# Altium schematic sheet Schematics.SchDoc
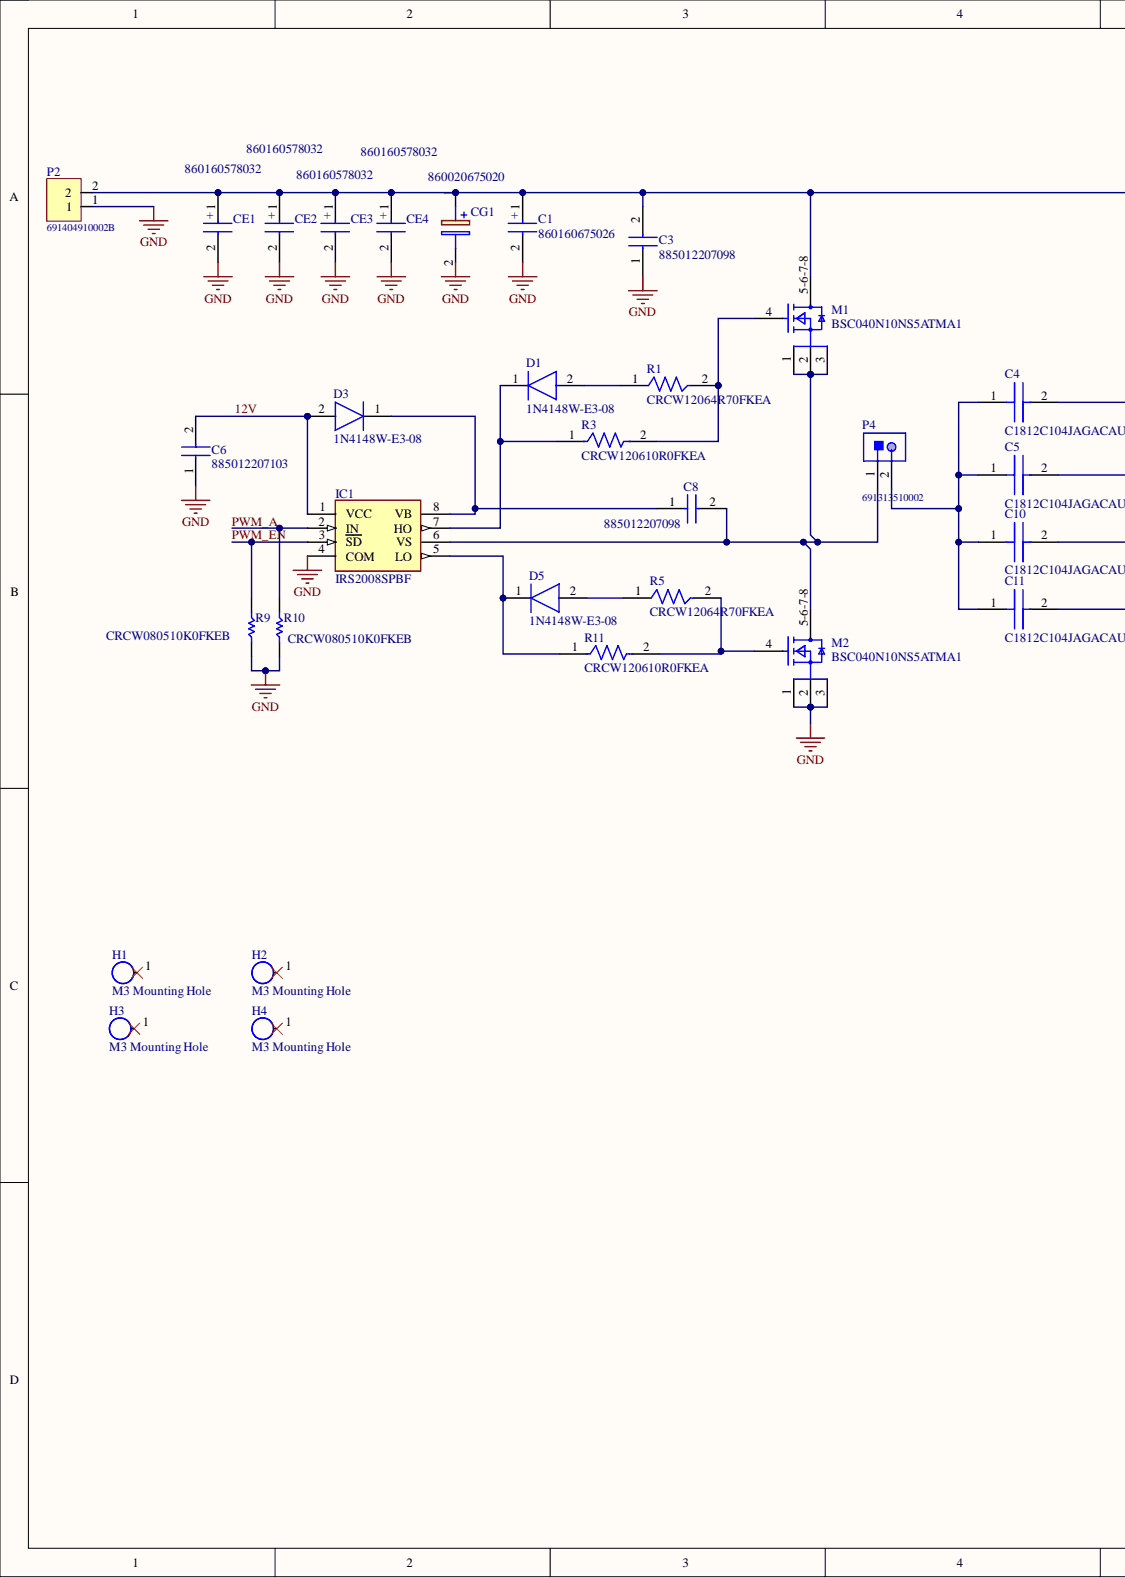
[diagram p0: Schematics.SchDoc, left]
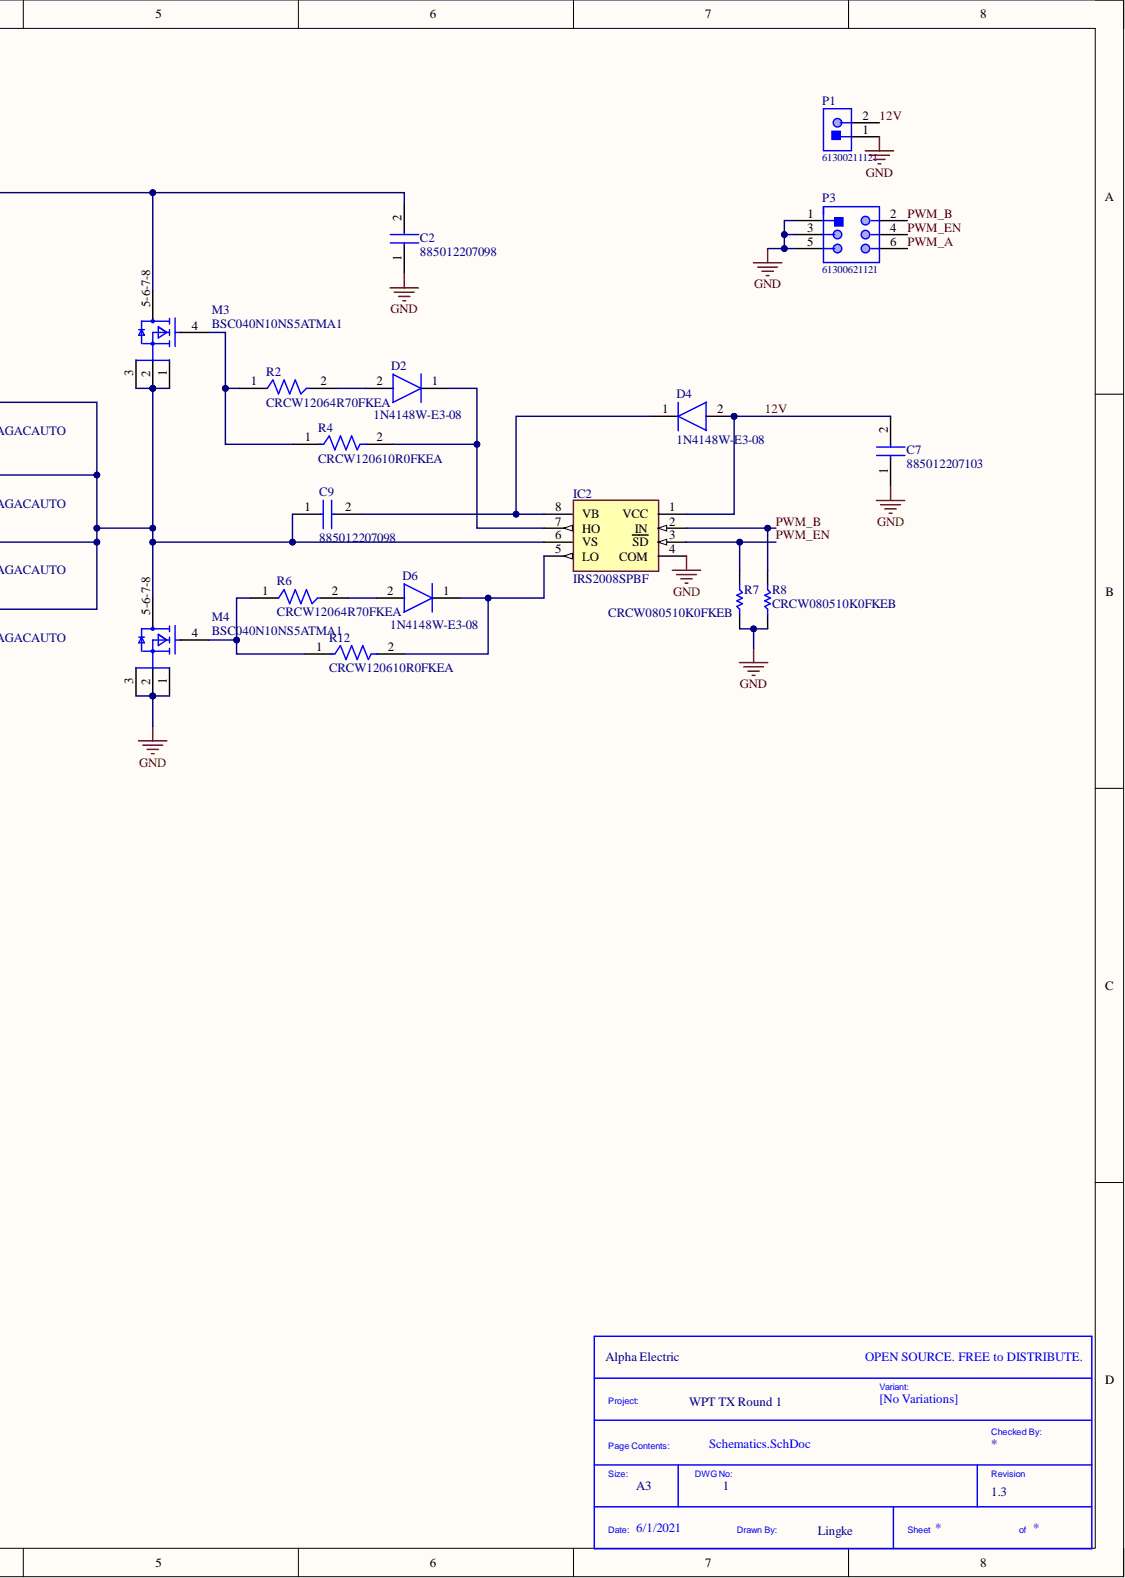
[diagram p1: Schematics.SchDoc, right]

## Parts
C1  860160675026  [CAPPRD500W62D1000H1750]
C2  885012207098  [CAPC2012X90N]
C3  885012207098  [CAPC2012X90N]
C4  C1812C104JAGACAUTO  [CAPC4532X220N]
C5  C1812C104JAGACAUTO  [CAPC4532X220N]
C6  885012207103  [CAPC2012X135N]
C7  885012207103  [CAPC2012X135N]
C8  885012207098  [CAPC2012X90N]
C9  885012207098  [CAPC2012X90N]
C10  C1812C104JAGACAUTO  [CAPC4532X220N]
C11  C1812C104JAGACAUTO  [CAPC4532X220N]
CE1  860160578032  [CAPPRD500W62D1300H2650]
CE2  860160578032  [CAPPRD500W62D1300H2650]
CE3  860160578032  [CAPPRD500W62D1300H2650]
CE4  860160578032  [CAPPRD500W62D1300H2650]
CG1  860020675020  [CAPPRD500W62D1000H1400]
D1  1N4148W-E3-08  [SOD3716X135N]
D2  1N4148W-E3-08  [SOD3716X135N]
D3  1N4148W-E3-08  [SOD3716X135N]
D4  1N4148W-E3-08  [SOD3716X135N]
D5  1N4148W-E3-08  [SOD3716X135N]
D6  1N4148W-E3-08  [SOD3716X135N]
H1  M3 Mounting Hole  [M3 Hole]
H2  M3 Mounting Hole  [M3 Hole]
H3  M3 Mounting Hole  [M3 Hole]
H4  M3 Mounting Hole  [M3 Hole]
IC1  IRS2008SPBF  [SOIC127P600X175-8N]
IC2  IRS2008SPBF  [SOIC127P600X175-8N]
M1  BSC040N10NS5ATMA1  [FP-PG-TDSON-8-MFG]
M2  BSC040N10NS5ATMA1  [FP-PG-TDSON-8-MFG]
M3  BSC040N10NS5ATMA1  [FP-PG-TDSON-8-MFG]
M4  BSC040N10NS5ATMA1  [FP-PG-TDSON-8-MFG]
P1  61300211121  [61300211121]
P2  691404910002B  [691404910002B]
P3  61300621121  [61300621121]
P4  691313510002  [691313510002]
R1  CRCW12064R70FKEA  [FP-CRCW1206-e3-IPC_A]
R2  CRCW12064R70FKEA  [FP-CRCW1206-e3-IPC_A]
R3  CRCW120610R0FKEA  [FP-CRCW1206-e3-IPC_A]
R4  CRCW120610R0FKEA  [FP-CRCW1206-e3-IPC_A]
R5  CRCW12064R70FKEA  [FP-CRCW1206-e3-IPC_A]
R6  CRCW12064R70FKEA  [FP-CRCW1206-e3-IPC_A]
R7  CRCW080510K0FKEB  [RESC2013X50X30ML20T20]
R8  CRCW080510K0FKEB  [RESC2013X50X30ML20T20]
R9  CRCW080510K0FKEB  [RESC2013X50X30ML20T20]
R10  CRCW080510K0FKEB  [RESC2013X50X30ML20T20]
R11  CRCW120610R0FKEA  [FP-CRCW1206-e3-IPC_A]
R12  CRCW120610R0FKEA  [FP-CRCW1206-e3-IPC_A]

## Nets
GND: C1.2 C2.1 C3.1 C6.1 C7.1 CE1.2 CE2.2 CE3.2 CE4.2 CG1.2 IC1.4(COM) IC2.4(COM) M2.1 M2.2 M2.3 M4.1 M4.2 M4.3 P1.1 P2.1 P3.1 P3.3 P3.5 R7.1 R8.1 R9.1 R10.1
12V: C6.2 C7.2 D3.2 D4.2 IC1.1(VCC) IC2.1(VCC) P1.2
PWM_A: IC1.2(IN) P3.6 R10.2
PWM_B: IC2.2(IN) P3.2 R8.2
PWM_EN: IC1.3(SD) IC2.3(SD) P3.4 R7.2 R9.2
NetC1_1: C1.1 C2.2 C3.2 CE1.1 CE2.1 CE3.1 CE4.1 CG1.1 M1.5-6-7-8 M3.5-6-7-8 P2.2
NetC4_1: C4.1 C5.1 C10.1 C11.1 P4.2
NetC4_2: C4.2 C5.2 C9.1 C10.2 C11.2 IC2.6(VS) M3.1 M3.2 M3.3 M4.5-6-7-8
NetC8_1: C8.1 D3.1 IC1.8(VB)
NetC8_2: C8.2 IC1.6(VS) M1.1 M1.2 M1.3 M2.5-6-7-8 P4.1
NetC9_2: C9.2 D4.1 IC2.8(VB)
NetD1_1: D1.1 IC1.7(HO) R3.1
NetD1_2: D1.2 R1.1
NetD2_1: D2.1 IC2.7(HO) R4.2
NetD2_2: D2.2 R2.2
NetD5_1: D5.1 IC1.5(LO) R11.1
NetD5_2: D5.2 R5.1
NetD6_1: D6.1 IC2.5(LO) R12.2
NetD6_2: D6.2 R6.2
NetM1_4: M1.4 R1.2 R3.2
NetM2_4: M2.4 R5.2 R11.2
NetM3_4: M3.4 R2.1 R4.1
NetM4_4: M4.4 R6.1 R12.1

Not connected: H1 1; H2 1; H3 1; H4 1

## Library data of the parts
C1 = Input Cap 220uF: Capacitor 220uF/50V; Manufacturer_Name: Wurth Elektronik; Component Kind: Standard; Height: 17.5mm; Library Name: Symbol Library.SchLib; Manufacturer_Part_Number: 860160675026; Supplier 1: Mouser; Supplier Part Number 1: 710-860160675026
C2 C3 C8 C9 = Input Cap 100nF: Capacitor 0.1uF/50V X7R; Manufacturer_Name: Wurth Elektronik; Component Kind: Standard; Datasheet Link: https://componentsearchengine.com//885012207098.pdf; Height: 0.9mm; Library Name: Symbol Library.SchLib; Manufacturer_Part_Number: 885012207098; Mouser2 Part Number: 710-885012207098; Mouser2 Price/Stock: https://www.mouser.com/Search/Refine.aspx?Keyword=710-885012207098; Supplier 1: Mouser; Supplier Part Number 1: 710-885012207098
C4 C5 C10 C11 = Resonant Tank Cap: Resistor; Manufacturer_Name: Kemet; Component Kind: Standard; Datasheet Link: https://api.kemet.com/component-edge/download/datasheet/C1812C104JAGACAUTO.pdf; Height: 2.2mm; Library Name: Symbol Library.SchLib; Manufacturer_Part_Number: C1812C104JAGACAUTO; Supplier 1: Mouser; Supplier Part Number 1: 80-C1812C104JAGAUTO
C6 C7 = 12V Fiter Cap: Capacitor; Manufacturer_Name: Wurth Elektronik; Component Kind: Standard; Datasheet Link: https://katalog.we-online.com/pbs/datasheet/885012207103.pdf; Height: 1.35mm; Library Name: Symbol Library.SchLib; Manufacturer_Part_Number: 885012207103; Supplier 1: Mouser; Supplier Part Number 1: 710-885012207103
CE1-CE4 = 1000uF Input Cap: Capacitor 1000uF Input Filtering; Manufacturer_Name: Wurth Elektronik; Component Kind: Standard; Datasheet Link: https://katalog.we-online.de/pbs/datasheet/860160578032.pdf; Height: 26.5mm; Library Name: Symbol Library.SchLib; Manufacturer_Part_Number: 860160578032; Supplier 1: Mouser; Supplier Part Number 1: 710-860160578032
CG1 = Ground Splitting Cap: Capacitor Polarised; Manufacturer_Name: Wurth Elektronik; Component Kind: Standard; Height: 14mm; Library Name: Symbol Library.SchLib; Manufacturer_Part_Number: 860020675020; Supplier 1: Mouser; Supplier Part Number 1: 710-860020675020
D1-D6 = BootStrap Diode: Diode; Manufacturer_Name: Vishay; Component Kind: Standard; Datasheet Link: https://componentsearchengine.com//1N4148W-E3-08.pdf; Height: 1.35mm; Library Name: Symbol Library.SchLib; Manufacturer_Part_Number: 1N4148W-E3-08; Mouser2 Part Number: 78-1N4148W-E3-08; Mouser2 Price/Stock: https://www.mouser.com/Search/Refine.aspx?Keyword=78-1N4148W-E3-08; Supplier 1: Digi-Key; Supplier Part Number 1: 1N4148W-E3-08CT-ND
H1-H4 = M3_Mounting_hole: Component Kind: Standard; Library Name: Symbol Library.SchLib
IC1 IC2 = Gate Driver IC: Integrated Circuit; Manufacturer_Name: Infineon; Component Kind: Standard; Height: 1.75mm; Library Name: Symbol Library.SchLib; Manufacturer_Part_Number: IRS2008SPBF; Supplier 1: Mouser; Supplier Part Number 1: 726-IRS2008SPBF
M1-M4 = Mosfet Switcher: MOSFET N-CH 100V 100A 8TDSON; Manufacturer: Infineon; Depletion Mode: False; Drain To Source Resistance: 3.4mΩ; Gate Charge At Vgs: 58nC @ 10V; Gate To Source Voltage: 20V; Input Capacitace At Vds: 4100pF @ 50V; Max Forward Diode Voltage: 1.1V; Max Junction Temp: +150°C; Number Of Channels: 1; Power Dissipation: 139W; Pulse Drain Current: 400A; Reverse Recovery Charge: 90nC; Reverse Recovery Time: 54ns; Rthja Max: 50K/W; Threshold Vgs Max: 3.8V; Threshold Vgs Min: 2.2V; Transconductance: 120S; Turn Off Delay Time: 32ns; Turn On Delay Time: 13ns; Typ Forward Diode Voltage: 0.9V; Automotive: No; Category: Trans; Component Kind: Standard; ComponentLink2Description: Datasheet; ComponentLink2URL: https://www.infineon.com/dgdl/Infineon-BSC040N10NS5-DS-v02_02-EN.pdf?fileId=5546d4624a0bf290014a0fbcee2e6b38; Continuous Drain Current: 100A; Device Class L1: Discrete Semiconductors; Device Class L2: Transistors; Device Class L3: MOSFETs; Digikey Description: MOSFET N-CH 100V 100A 8TDSON; Drain To Source Breakdown Voltage: 100V; Drain To Source Voltage: 100V; Footprint Url: https://www.infineon.com/dgdl/Infineon-PG-TDSON-8-7-FP-v01_00-EN.pdf?fileId=5546d46259d9a4bf015a1b6adadd1f0a; Height: 1.1mm; Lead Free: Yes; Library Name: Symbol Library.SchLib; Manufacturer Part Number: BSC040N10NS5ATMA1; Mouser Description: MOSFET N-Ch 100V 100A TDSON-8; Number Of N Channels: 1; Octopart: https://octopart.com/bsc040n10ns5atma1-infineon-52825711; Package: PG-TDSON-8; RoHS: TRUE; Supplier 1: Mouser; Supplier Part Number 1: 726-BSC040N10NS5ATMA; Temperature: -55°C to +150°C; Temperature Range High: +150°C; Temperature Range Low: -55°C
P1 = 12V Input: THT Vertical Pin Header WR-PHD, Pitch 2.54 mm, Single Row, 2 pins; Manufacturer: Wurth Elektronik; Contact Resistance: 20mOhm; Gender: Male; Pin Number: 2; Pitch: 2.54mm; Rated Current: 3A; Withstanding Voltage: 500VAC; Working Voltage: 250VAC; Component Kind: Standard; Datasheet URL: https://katalog.we-online.de/em/datasheet/6130xx11121.pdf; Library Name: Symbol Library.SchLib; Manufacturer URL: http://www.we-online.de; Mounting Technology: THT; Operating Temperature: -40to105degC; Orientation: Vertical; Package Reference: 61300211121; Packaging: Bag; Part Number: 61300211121; Row Number: Single; Supplier 1: Mouser; Supplier Part Number 1: 710-61300211121; Publisher: WurthElektronik
P2 = Power Input: Serie 4049 B - 7.50mm Screwless Clamp Power Connector WR-TBL, 2 pin; Manufacturer: Wurth Elektronik; Pin Number: 2; Component Kind: Standard; Datasheet URL: http://katalog.we-online.de/em/datasheet/6914049100xxB.pdf; Library Name: Symbol Library.SchLib; Manufacturer URL: http://www.we-online.de; Mounting Technology: THT; Operating Temperature: -40to105degC; Package Reference: 691404910002B; Packaging: Box; Part Number: 691404910002B; Pitch: 7.50mm; Supplier 1: Mouser; Supplier Part Number 1: 710-691404910002B; Publisher: WurthElektronik
P3 = PWM Input Dual Pin: THT Vertical Pin Header WR-PHD, Pitch 2.54 mm, Dual Row, 6 pins; Manufacturer: Wurth Elektronik; Contact Resistance: 20mOhm; Gender: Male; Pin Number: 6; Pitch: 2.54mm; Rated Current: 3A; Withstanding Voltage: 500VAC; Working Voltage: 250VAC; Component Kind: Standard; Datasheet URL: https://katalog.we-online.de/em/datasheet/6130xx21121.pdf; Library Name: Symbol Library.SchLib; Manufacturer URL: http://www.we-online.de; Mounting Technology: THT; Operating Temperature: -40to105degC; Orientation: Vertical; Package Reference: 61300621121; Packaging: Bag; Part Number: 61300621121; Row Number: Dual; Supplier 1: Mouser; Supplier Part Number 1: 710-61300621121; Publisher: WurthElektronik
P4 = Power Output: Serie 313 - 5.08mm Close Horizontal PCB Header WR-TBL, 2 pin; Manufacturer: Wurth Elektronik; Gender: Male; Pin Number: 2; Component Kind: Standard; Datasheet URL: http://katalog.we-online.de/em/datasheet/6913135100xx.pdf; Library Name: Symbol Library.SchLib; Manufacturer URL: http://www.we-online.de; Mounting Technology: THT; Operating Temperature: -40to105degC; Package Reference: 691313510002; Packaging: Box; Part Number: 691313510002; Pitch: 5.08mm; Supplier 1: Mouser; Supplier Part Number 1: 710-691313510002; Publisher: WurthElektronik
R1 R2 R5 R6 = MOSFET Gate Fast-off Resistor 4.7Ohm: RES Thick Film, 4.7Ω, 1%, 0.25W, 100ppm/°C, 1206; Max Power Dissipation: 250mW; Resistance: 4.7R; Voltage Rating: 200V; Case Code (Imperial): 1206; Case Code (Metric): 3216; Case/Package: 1206; Component Kind: Standard; Composition: Thick Film; Contact Plating: Tin; Depth: 1.6mm; Features: Automotive AEC-Q200; Height: 0.024inch; Length: 3.2mm; Library Name: Symbol Library.SchLib; Max Operating Temperature: 155°C; Military Standard: Not; Min Operating Temperature: -55°C; Mount: Surface Mount; Number of Pins: 2; Number of Terminations: 2; Package Quantity: 10; Packaging: Tape and Reel; Power Rating: 250mW; Radiation Hardening: No; Supplier 1: Mouser; Supplier Part Number 1: 71-CRCW12064R70FKEA; Temperature Coefficient: 100ppm/°C; Tolerance: 1%; Weight: 0.000353oz; Width: 0.063inch
R3 R4 R11 R12 = MOSFET Gate Resistor 10Ohm: RES Thick Film, 10Ω, 1%, 0.25W, 100ppm/°C, 1206; Max Power Dissipation: 250mW; Resistance: 10R; Voltage Rating: 200V; Case Code (Imperial): 1206; Case Code (Metric): 3216; Case/Package: 1206; Component Kind: Standard; Composition: Thick Film; Contact Plating: Tin; Depth: 1.6mm; Features: Automotive AEC-Q200; Height: 0.55mm; Lead Free: Lead Free; Length: 3.2mm; Library Name: Symbol Library.SchLib; Max Operating Temperature: 155°C; Military Standard: Not; Min Operating Temperature: -55°C; Number of Pins: 2; Number of Terminations: 2; Package Quantity: 10; Packaging: Tape and Reel; Power Rating: 250mW; Radiation Hardening: No; Supplier 1: Digi-Key; Supplier Part Number 1: 541-10.0FDKR-ND; Temperature Coefficient: 100ppm/°C; Termination: SMD/SMT; Tolerance: 1%; Voltage Rating (DC): 200V; Weight: 0.000353oz; Width: 0.063inch
R7-R10 = PWM Pull Down Resistor: Manufacturer: Vishay Dale; Component Kind: Standard; Library Name: Symbol Library.SchLib; Part Number: CRCW080510K0FKEB; Supplier 1: Mouser; Supplier Part Number 1: 71-CRCW080510K0FKEB
